# Altium schematic sheet [5]GPIOs.SchDoc
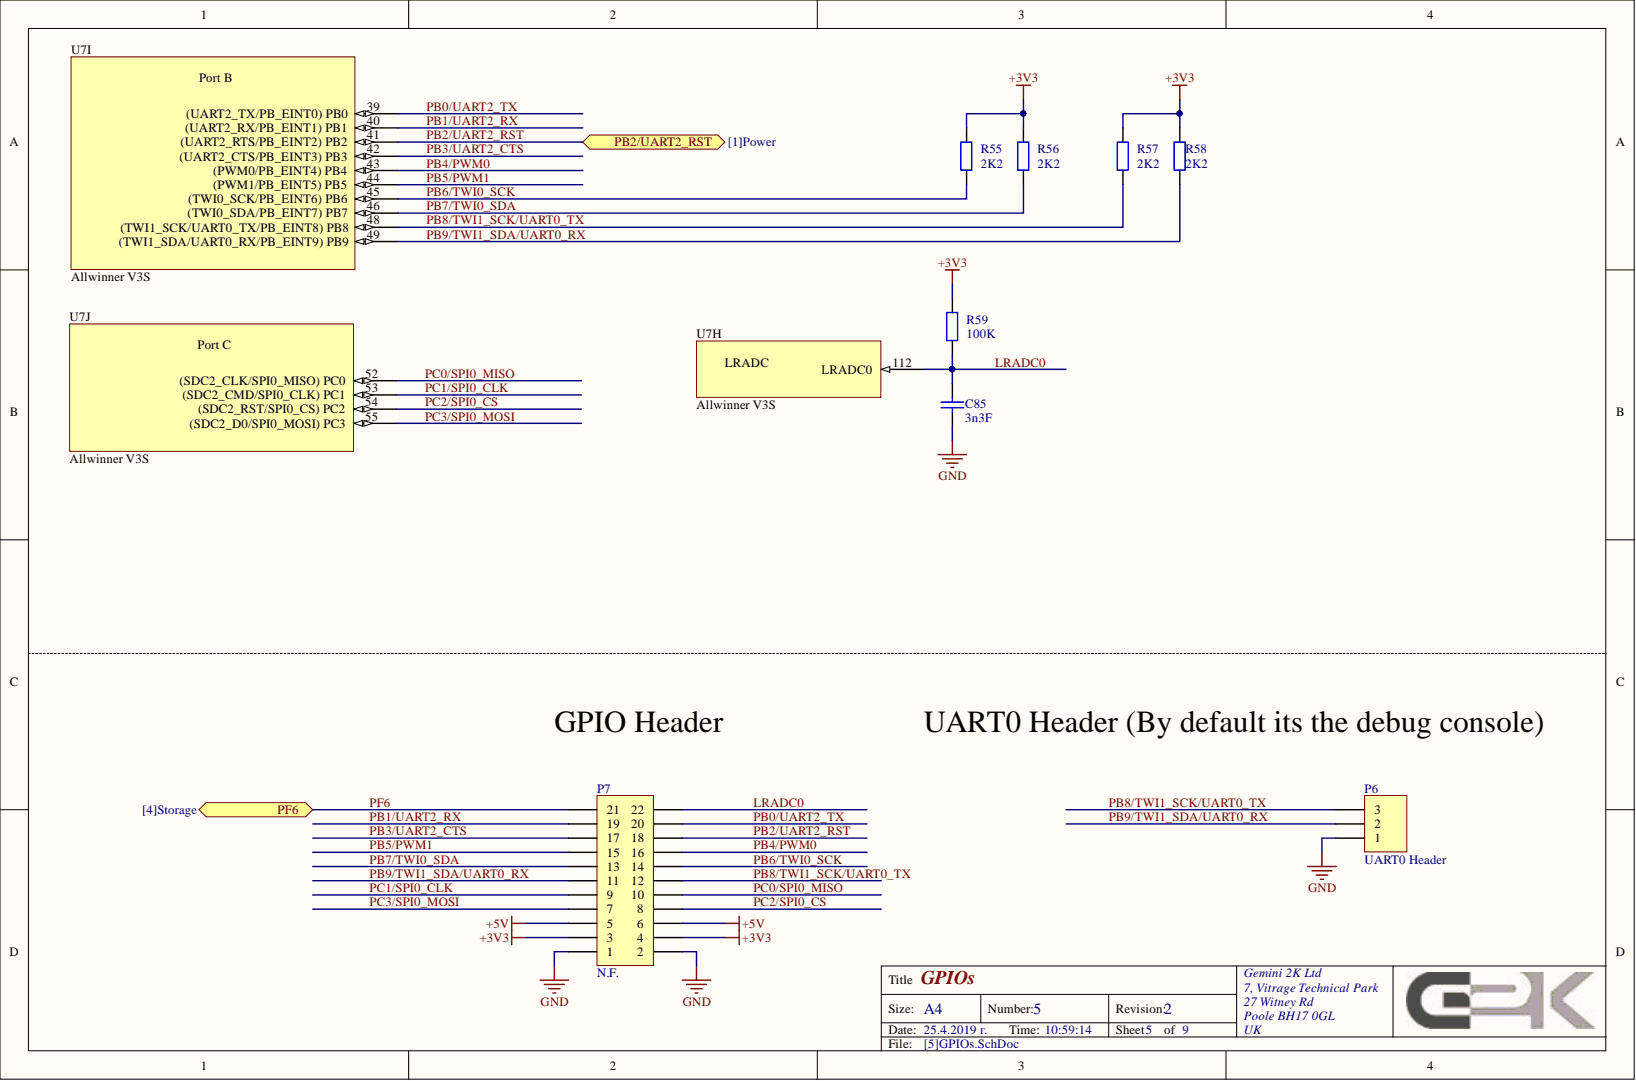
[diagram p0: [5]GPIOs.SchDoc]

## Parts
C85  value 3n3F  [1608[0603]]  (hidden comment: 0603)
P6  UART0 Header  [HDR1X3]
P7  N.F.  [HDR2X11]
R55  value 2K2  [J1-0603]  (hidden comment: 0603)
R56  value 2K2  [J1-0603]  (hidden comment: 0603)
R57  value 2K2  [J1-0603]  (hidden comment: 0603)
R58  value 2K2  [J1-0603]  (hidden comment: 0603)
R59  value 100K  [J1-0603]  (hidden comment: 0603)
U7  Allwinner V3S  Manufacturer Name: Allwinner  Manufacturer Part No.: V3S  [LQFP128 E-PAD - Low Density]  (parts H,I,J)

## Ports
PB2/UART2_RST, PF6

## Nets
+3V3: P7.3 P7.4 R55.2 R56.2 R57.2 R58.2 R59.2
+5V: P7.5 P7.6
GND: C85.1 P6.1 P7.1 P7.2
LRADC0: C85.2 P7.22 R59.1 U7.112(LRADC0)
PB0/UART2_TX: P7.20 U7.39((UART2_TX/PB_EINT0)_PB0)
PB1/UART2_RX: P7.19 U7.40((UART2_RX/PB_EINT1)_PB1)
PB2/UART2_RST: P7.18 U7.41((UART2_RTS/PB_EINT2)_PB2) ; port PB2/UART2_RST
PB3/UART2_CTS: P7.17 U7.42((UART2_CTS/PB_EINT3)_PB3)
PB4/PWM0: P7.16 U7.43((PWM0/PB_EINT4)_PB4)
PB5/PWM1: P7.15 U7.44((PWM1/PB_EINT5)_PB5)
PB6/TWI0_SCK: P7.14 R55.1 U7.45((TWI0_SCK/PB_EINT6)_PB6)
PB7/TWI0_SDA: P7.13 R56.1 U7.46((TWI0_SDA/PB_EINT7)_PB7)
PB8/TWI1_SCK/UART0_TX: P6.3 P7.12 R57.1 U7.48((TWI1_SCK/UART0_TX/PB_EINT8)_PB8)
PB9/TWI1_SDA/UART0_RX: P6.2 P7.11 R58.1 U7.49((TWI1_SDA/UART0_RX/PB_EINT9)_PB9)
PC0/SPI0_MISO: P7.10 U7.52((SDC2_CLK/SPI0_MISO)_PC0)
PC1/SPI0_CLK: P7.9 U7.53((SDC2_CMD/SPI0_CLK)_PC1)
PC2/SPI0_CS: P7.8 U7.54((SDC2_RST/SPI0_CS)_PC2)
PC3/SPI0_MOSI: P7.7 U7.55((SDC2_D0/SPI0_MOSI)_PC3)
PF6: P7.21 ; port PF6

## Library data of the parts
C85 = Cap: Capacitor; Note: Get a lot more of those.; Case Code (in): 0603; Component Kind: Standard; Distributor Link: https://uk.farnell.com/multicomp/mc0603b332k500ct/cap-3300pf-50v-10-x7r-0603/dp/1759095; LatestRevisionDate: 17-Jul-2002; LatestRevisionNote: Re-released for DXP Platform.; Library Name: Miscellaneous Devices.IntLib; PackageDescription: Radial Cap, Thru-Hole; 2 Leads; 0.3 in Pin Spacing; PackageReference: RAD-0.3; Published: 8-Jun-2000; Signal Integrity: Cap; Simulation: CAP; Publisher: Altium Limited
P6 = Header 3: Header, 3-Pin; Component Kind: Standard; LatestRevisionDate: 17-Jul-2002; LatestRevisionNote: Re-released for DXP Platform.; Library Name: Miscellaneous Connectors.IntLib; Signal Integrity: Connector; Publisher: Altium Limited
P7 = Header 11X2: Header, 11-Pin, Dual row; Component Kind: Standard; LatestRevisionDate: 17-Jul-2002; LatestRevisionNote: Re-released for DXP Platform.; Library Name: Miscellaneous Connectors.IntLib; Signal Integrity: Connector; Value: N.F.; Publisher: Altium Limited
R55-R59 = Res2: Resistor; Case Code (in): 0603; Component Kind: Standard; LatestRevisionDate: 17-Jul-2002; LatestRevisionNote: Re-released for DXP Platform.; Library Name: Miscellaneous Devices.IntLib; PackageDescription: Axial Device, Thru-Hole; 2 Leads; 0.4 in Pin Spacing; PackageReference: AXIAL-0.4; Published: 8-Jun-2000; Signal Integrity: Res; Simulation: RESISTOR; Publisher: Altium Limited
  R55-R58: Distributor Link: https://uk.farnell.com/yageo/rc0603fr-072k2l/res-2k2-1-0-1w-0603-thick-film/dp/9238522
  R59: Distributor Link: https://eu.mouser.com/ProductDetail/Vishay-Draloric/RCA0603100KJNEC?qs=sGAEpiMZZMvdGkrng054twKDKoBh%252bscnvgxPku908uc%3d
U7 = Allwinner V3S: Component Kind: Standard; Library Name: Allwinner.SchLib
